# Altium schematic sheet SD.SchDoc
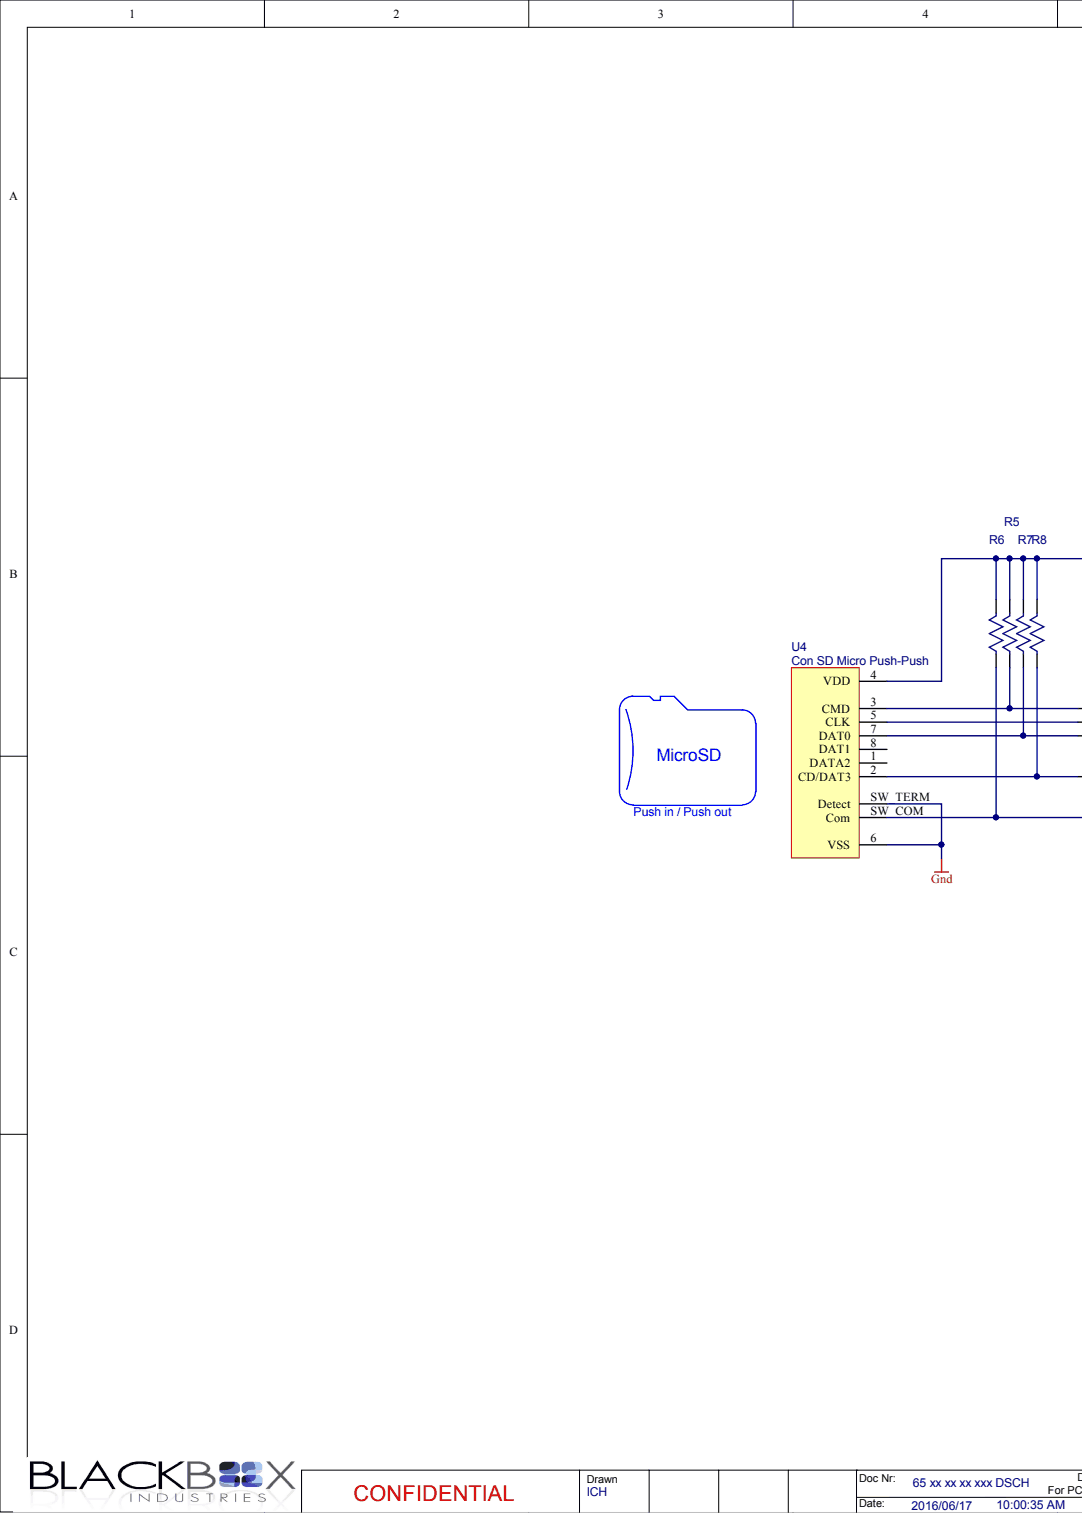
[diagram p0: SD.SchDoc, left]
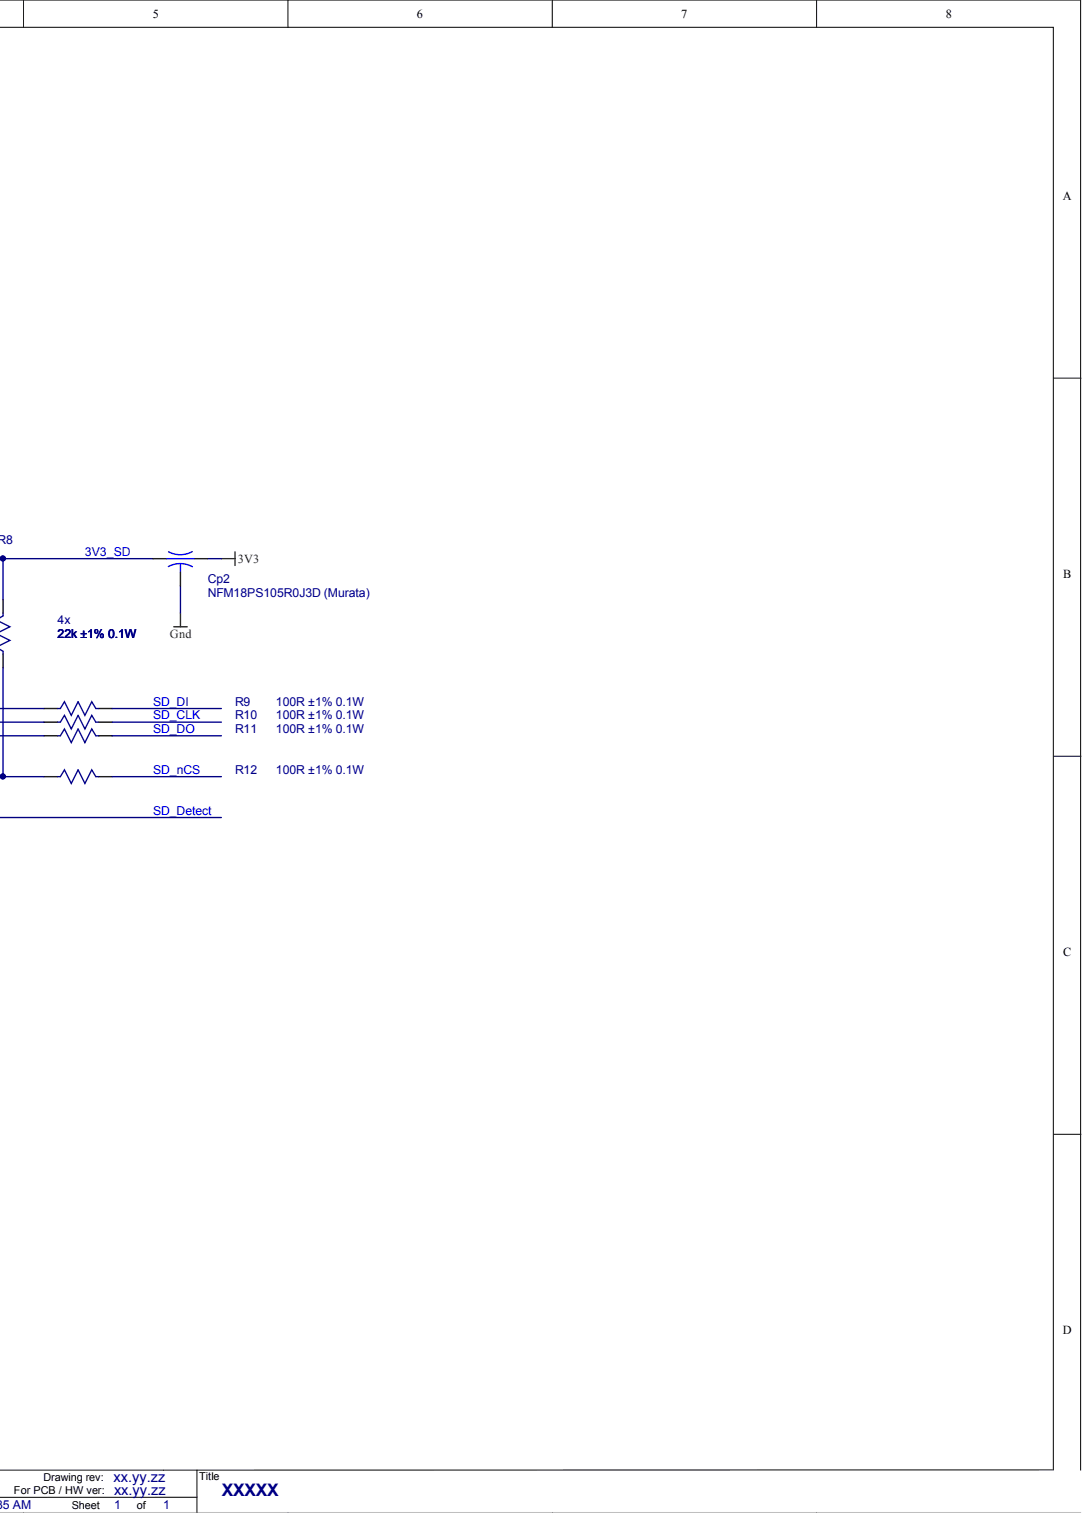
[diagram p1: SD.SchDoc, right]

## Parts
Cp2  NFM18PS105R0J3D (Murata)  [NFM18PS (Murata)]
R5  22k ±1% 0.1W  [0603_RES]  (value not drawn)
R6  22k ±1% 0.1W  [0603_RES]  (value not drawn)
R7  22k ±1% 0.1W  [0603_RES]  (value not drawn)
R8  22k ±1% 0.1W  [0603_RES]  (value not drawn)
R9  100R ±1% 0.1W  [0603_RES]
R10  100R ±1% 0.1W  [0603_RES]
R11  100R ±1% 0.1W  [0603_RES]
R12  100R ±1% 0.1W  [0603_RES]
U4  Con SD Micro Push-Push  [5031821853 <MOLEX>]

## Nets
3V3: Cp2.2
GND: Cp2.3 U4.6(VSS) U4.SW_TERM(Detect)
3V3_SD: Cp2.1 R5.2 R6.2 R7.2 R8.2 U4.4(VDD)
SD_CLK: R10.2
SD_DETECT: R6.1 U4.SW_COM(Com)
SD_DI: R9.2
SD_DO: R11.2
SD_NCS: R12.2
NetR5_1: R5.1 R9.1 U4.3(CMD)
NetR7_1: R7.1 R11.1 U4.7(DAT0)
NetR8_1: R8.1 R12.1 U4.2(CD/DAT3)
NetR10_1: R10.1 U4.5(CLK)

Not connected: U4 1(DATA2) 8(DAT1)

## Library data of the parts
Cp2 = NFM18PS105R0J3D (Murata): Passthrough cap 1uF 2A 6.3V; ClassName: PassThroughCaps; Component Kind: Standard; descr: Passthrough cap 1uF 2A 6.3V; Supplier 1: Digi-Key; Supplier Part Number 1: 490-6974-1-ND; Library Name: Onecell Ig.IntLib
R5-R8 = 22k ±1% 0.1W: resistor, thickfilm; Component Kind: Standard; descr: resistor, thickfilm; Library Name: OneCell passives.IntLib; Supplier 1: Digi-Key; Supplier Part Number 1: 311-22.0KHRTR-ND
R9-R12 = 100R ±1% 0.1W: resistor, thickfilm; Component Kind: Standard; descr: resistor, thickfilm; Library Name: OneCell passives.IntLib; Supplier 1: Digi-Key; Supplier Part Number 1: 311-100HRTR-ND
U4 = 5031821853 <MOLEX>: Component Kind: Standard; descr: Con SD Micro Push-Push; Description: Con SD Micro Push-Push; Supplier 1: Digi-Key; Supplier Part Number 1: WM9750CT-ND; Library Name: Onecell Ig.SchLib
